# Altium schematic sheet HT3.SchDoc(HT3)
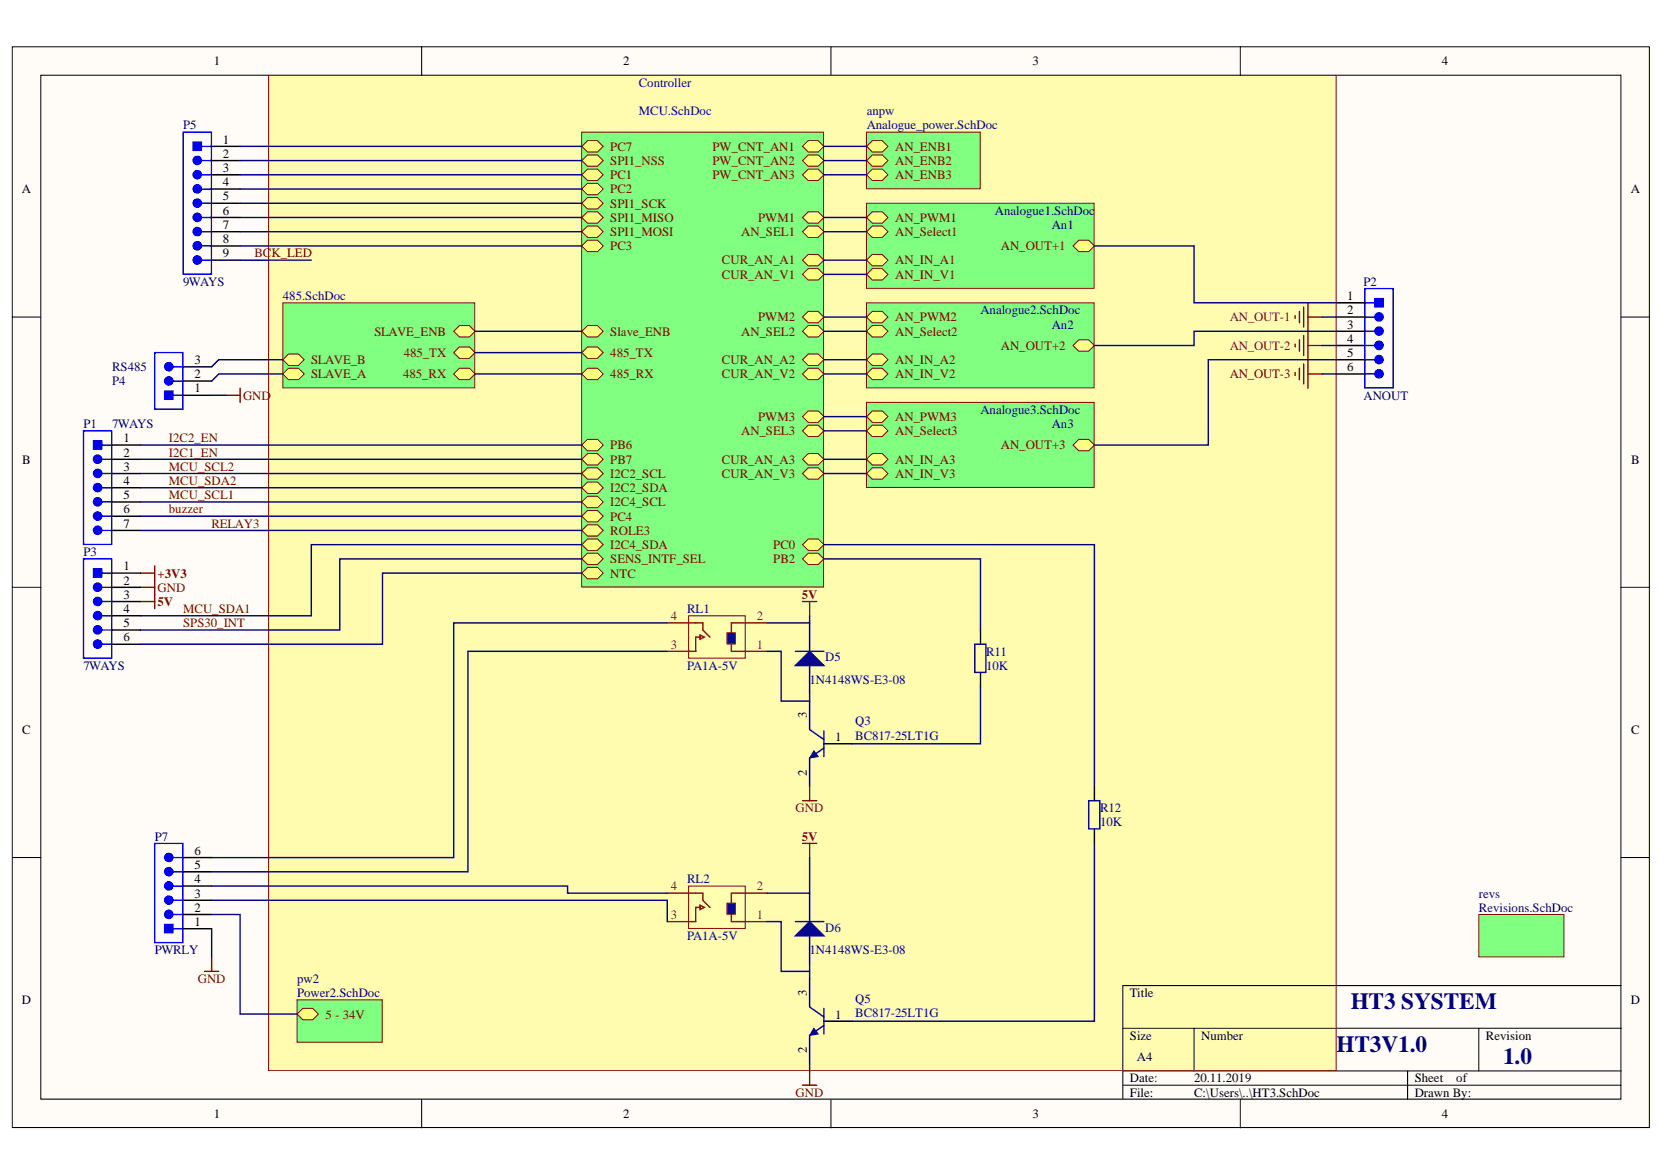
[diagram p0: HT3.SchDoc]

## Parts
D5  1N4148WS-E3-08  [VISH-SOD-323-2_V]
D6  1N4148WS-E3-08  [VISH-SOD-323-2_V]
P1  7WAYS  [1.27 x 7 pin]
P2  ANOUT  [6pinHT2]
P3  7WAYS  [1.27 x 6 pin]
P4  RS485  [3pinHT2]
P5  9WAYS  [1.27 x 9 pin]
P7  PWRLY  [6pinHT2]
Q3  BC817-25LT1G  [ONSC-SOT-23-3-318-08_V]
Q5  BC817-25LT1G  [ONSC-SOT-23-3-318-08_V]
R11  10K  [R0603]
R12  10K  [R0603]
RL1  PA1A-5V  [PA1A-5V]
RL2  PA1A-5V  [PA1A-5V]

## Nets
5V: D5.2 D6.2 P3.3 RL1.2 RL2.2
+3V3: P3.1
AN_OUT-1: P2.2
AN_OUT-2: P2.4
AN_OUT-3: P2.6
GND: P3.2 P4.1 P7.1 Q3.2 Q5.2
BCK_LED: P5.9
BUZZER: P1.6
I2C1_EN: P1.2
I2C2_EN: P1.1
MCU_SCL1: P1.5
MCU_SCL2: P1.3
MCU_SDA1: P3.4
MCU_SDA2: P1.4
RELAY3: P1.7
SPS30_INT: P3.5
NetD5_1: D5.1 Q3.3 RL1.1
NetD6_1: D6.1 Q5.3 RL2.1
NetP2_1: P2.1
NetP2_3: P2.3
NetP2_5: P2.5
NetP3_6: P3.6
NetP4_2: P4.2
NetP4_3: P4.3
NetP5_1: P5.1
NetP5_2: P5.2
NetP5_3: P5.3
NetP5_4: P5.4
NetP5_5: P5.5
NetP5_6: P5.6
NetP5_7: P5.7
NetP5_8: P5.8
NetP7_2: P7.2
NetP7_3: P7.3 RL2.3
NetP7_4: P7.4 RL2.4
NetP7_5: P7.5 RL1.3
NetP7_6: P7.6 RL1.4
NetQ3_1: Q3.1 R11.2
NetQ5_1: Q5.1 R12.2
NetR11_1: R11.1
NetR12_1: R12.1
485_RX:
485_TX:
AN_ENB1:
AN_ENB2:
AN_ENB3:
AN_IN_A1:
AN_IN_A2:
AN_IN_A3:
AN_IN_V1:
AN_IN_V2:
AN_IN_V3:
AN_PWM1:
AN_PWM2:
AN_PWM3:
AN_SELECT1:
AN_SELECT2:
AN_SELECT3:
SLAVE_ENB:

## Library data of the parts
D5 D6 = CMP-2000-05517-1: DIODE FAST SWITCHING Single 150mA 100V SMT SOD323; Manufacturer: Vishay; Brand: VishaySemiconductors; Forward Voltage Drop: 1.2V; Maximum Diode Capacitance: 4pF; Maximum Power Dissipation: 200mW; Maximum Reverse Leakage Current: 100uA; Max Surge Current: 350mA; Package Version: Rev. 5, 09/2009; Recovery Time: 4ns; Unit Weight: 0.000152oz; Component Kind: Standard; Datasheet URL: http://www.vishay.com/docs/85751/1n4148ws.pdf; Datasheet Version: Rev. 2.0, 05/2013; Factory Pack Quantity: 3000; Forward Continuous Current: 150mA; Library Name: Altium Content Vault; Manufacturer URL: http://www.vishay.com/; Maximum Operating Temperature: +150C; Minimum Operating Temperature: -55C; Mounting Style: SMD/SMT; Mounting Technology: SurfaceMount; Operating Temperature Range: -55Cto+150C; Package / Case: SOD-323; Package Description: 2-Pin Surface Mount Device, Body 1.775 x 1.3 mm, Height 1.15 mm; Package Reference: SOD-323-2; Package URL: http://www.st.com/st-web-ui/static/active/en/resource/technical/document/datasheet/CD00233088.pdf; Packaging: Reel; Part Number: 1N4148WS-E3-08; Peak Reverse Voltage: 100V; Product: SwitchingDiodes; Product Category: Diodes-GeneralPurpose,Power,Switching; RoHS: Details; Series: 1N4148WS
P1 = TERM BLOCK 7PIN: Component Kind: Standard; Library Name: Orh_Gntk.SCHLIB
P2 P3 P7 = TERM BLOCK 6PIN: Component Kind: Standard; Library Name: Orh_Gntk.SCHLIB
P4 = TERM BLOCK 3PIN: TERMINAL BLOCK VERTICAL 3 PIN; Component Kind: Standard; Library Name: Orh_Gntk.SCHLIB
P5 = TERM BLOCK 9PIN: TERM.BLK.5.08MM 9P 180C MALE GREEN; Part Number: 2EDGV-5.08-09P-14-00CH; Component Kind: Standard; Library Name: Orh_Gntk.SCHLIB
Q3 Q5 = CMP-1048-00737-1: TRANSISTOR NPN 0.5A 45V SOT23 HOTTECH; Manufacturer: On Semiconductor; fT Min (MHz): 100; IC Continuous (A): 0.5; Packing: Tape and Reel; Component Kind: Standard; ComponentLink1Description: Manufacturer URL; ComponentLink1URL: http://www.onsemi.com/; ComponentLink2Description: Datasheet; ComponentLink2URL: http://www.onsemi.com/pub_link/Collateral/BC817-16LT1-D.PDF; ComponentLink3Description: Package Specification; ComponentLink3URL: http://www.onsemi.cn/pub_link/Collateral/318-08.PDF; DatasheetVersion: Rev. 12; Library Name: Altium Content Vault; Mounting Technology: Surface Mount; PackageDescription: 3-Pin Small Outline Transistor, Body 2.92 x 1.3 mm, Pitch 0.95 mm; PackageReference: SOT-23-3-318-08; PackageVersion: Rev. AP, 11/2009; Part Number: BC817-25LT1G; Polarity: NPN; RoHS: TRUE
R11 R12 = Res 20K/%5: RES.0603 10K 1% 0,063W; Part Number: MC0063W0603110K; Component Kind: Standard; Library Name: orh.SCHLIB
RL1 RL2 = PA1A-5V: RELAY 5A 5V (1a) PANASONIC; Component Kind: Standard; Digi-Key Part Number: 255-1738-5-ND; Manufacturer Part Number: PA1A-5V; Özdisan: http://www.ozdisan.com/elektromekanik-komponentler/role-role-soketleri/ince-guc-roleleri/PA1A-5V; Ürün Kodu: PA1A-5V; Library Name: Orh_Gntk.SCHLIB
